# Altium schematic sheet Sheet3.SchDoc(Sheet3)
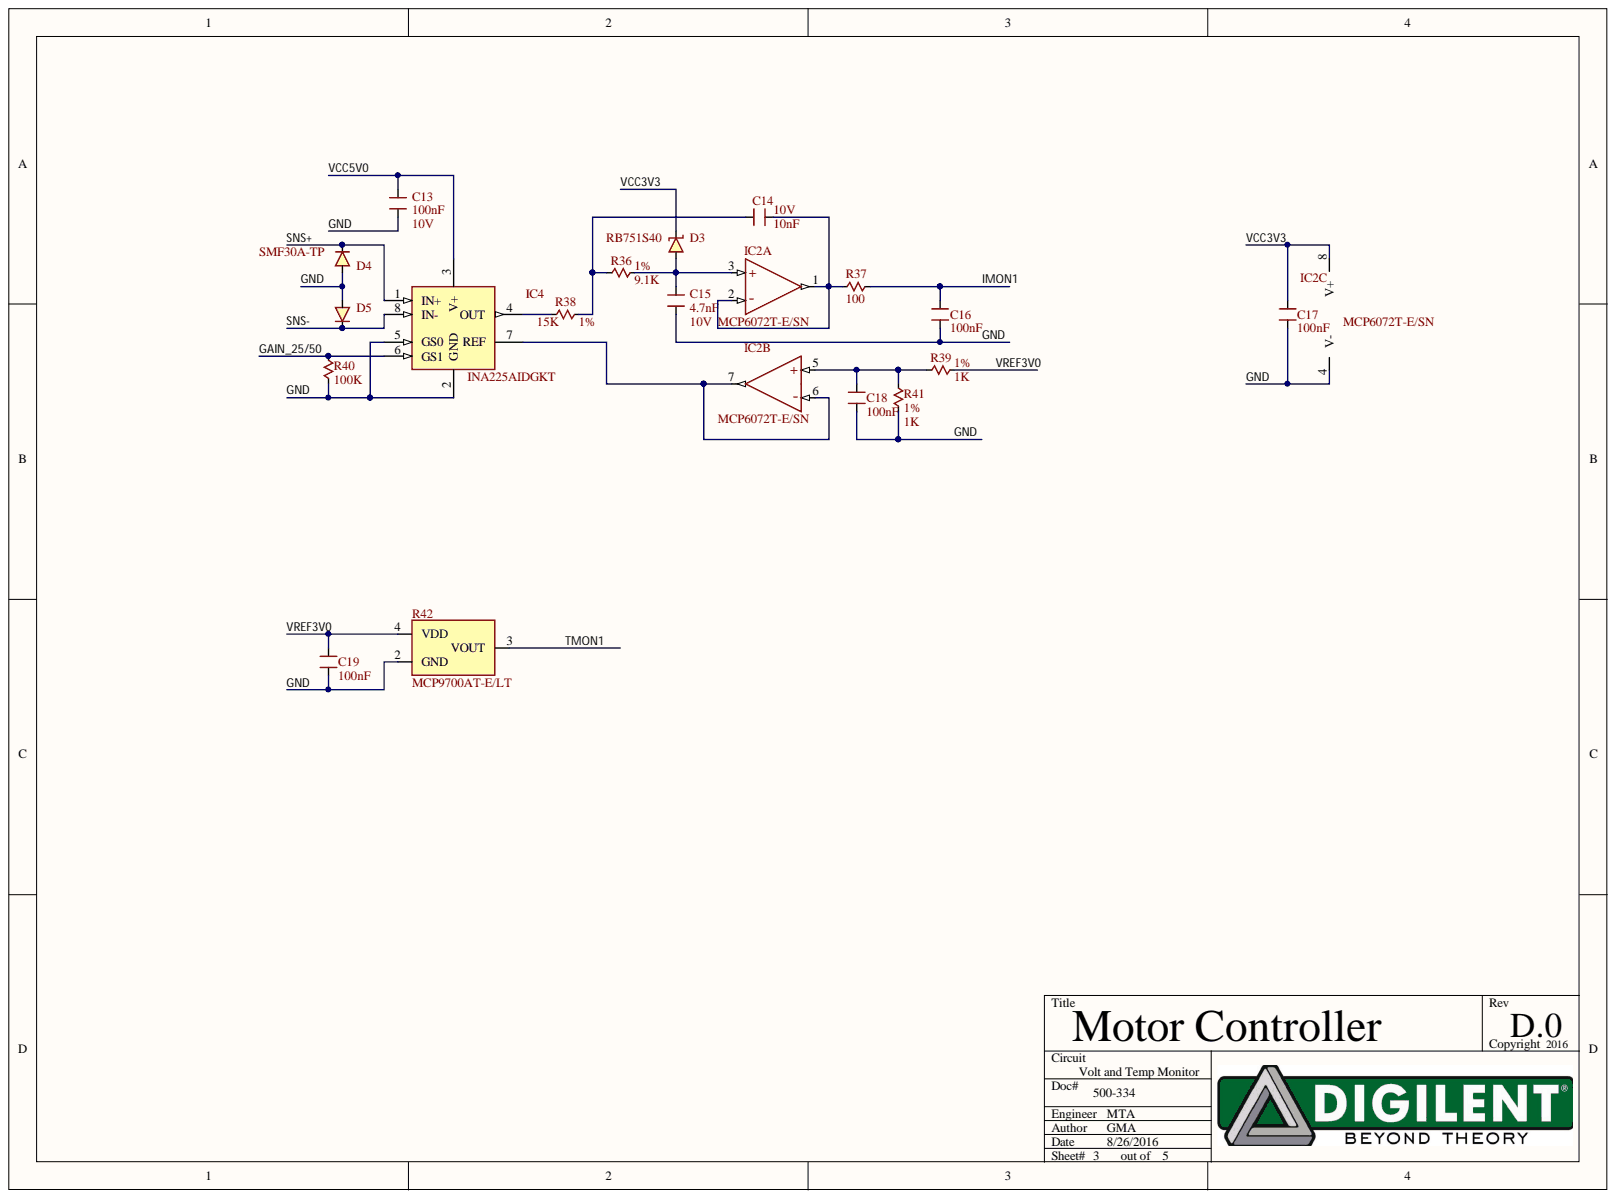
[diagram p0: Sheet3.SchDoc]

## Parts
C13  10V  value 100nF  [CAP0402]
C14  10V  value 10nF  [CAP0402]
C15  10V  value 4.7nF  [CAP0402]
C16  value 100nF  [CAP0402]  (hidden comment: CAPACITOR)
C17  value 100nF  [CAP0402]  (hidden comment: CAPACITOR)
C18  value 100nF  [CAP0402]  (hidden comment: CAPACITOR)
C19  value 100nF  [CAP0402]  (hidden comment: CAPACITOR)
D3  RB751S40  [SOD-523]
D4  SMF30A-TP  [SOD123]
D5  SMF30A-TP  [SOD123]
IC2  MCP6072T-E/SN  [SOIC8-MICROCHIP]  (parts A,B,C)
IC4  INA225AIDGKT  [MSOP8]
R36  1%  value 9.1K  [RES0402]
R37  value 100  [RES0402]  (hidden comment: RESISTOR)
R38  1%  value 15K  [RES0402]
R39  1%  value 1K  [RES0402]
R40  value 100K  [RES0402]  (hidden comment: RESISTOR)
R41  1%  value 1K  [RES0402]
R42  MCP9700AT-E/LT  [SC70-5]

## Nets
GAIN_25/50: IC4.6(GS1) R40.1
GND: C13.2 C15.2 C16.2 C17.2 C18.2 C19.2 D4.A D5.A IC2.4(V-) IC4.2(GND) IC4.5(GS0) R40.2 R41.2 R42.2
IMON1: C16.1 R37.2
SNS+: D4.K IC4.1(IN+)
SNS-: D5.K IC4.8(IN-)
TMON1: R42.3
VCC3V3: C17.1 D3.K IC2.8(V+)
VCC5V0: C13.1 IC4.3(V+)
VREF3V0: C19.1 R39.1 R42.4
NetC14_1: C14.1 R36.2 R38.1
NetC14_2: C14.2 IC2.1 IC2.2(-) R37.1
NetC15_1: C15.1 D3.A IC2.3(+) R36.1
NetC18_1: C18.1 IC2.5(+) R39.2 R41.1
NetIC2_6: IC2.6(-) IC2.7 IC4.7(REF)
NetIC4_4: IC4.4(OUT) R38.2

## Library data of the parts
C13-C19 = CAPACITOR: LoadStatus: Load; Component Kind: Standard; Description: Capacitor; Library Name: DigilentUN.IntLib
D3 = DIODE-SCH: Schotkey Diode NOT GENERIC; Manufacturer: Fairchild Semi; LoadStatus: Load; Component Kind: Standard; Library Name: DigilentUN.IntLib
D4 D5 = DIODE: Surface Mount Schottky Rectifier; Manufacturer: Vishay; LoadStatus: Load; Component Kind: Standard; Library Name: DigilentUN.IntLib
IC2 = MCP6072: 110uA, High Precision Op Amp; Manufacturer: Microchip; LoadStatus: Load; Component Kind: Standard; Library Name: DigilentUN.IntLib
IC4 = INA225: Voltage Output, Programable-Gain, Bi-Directional Zero Drift Series Current Shunt Monitor; Manufacturer: Texas Instruments; LoadStatus: Load; Component Kind: Standard; Library Name: DigilentUN.IntLib
R36-R41 = RESISTOR: LoadStatus: Load; Component Kind: Standard; Description: Resistor; Library Name: DigilentUN.IntLib
R42 = MCP9700A: Low-Power Linear Active Thermisto IC; Manufacturer: Microchip; LoadStatus: Load; Component Kind: Standard; Library Name: DigilentUN.IntLib
